# Altium schematic sheet N95Decon.SchDoc
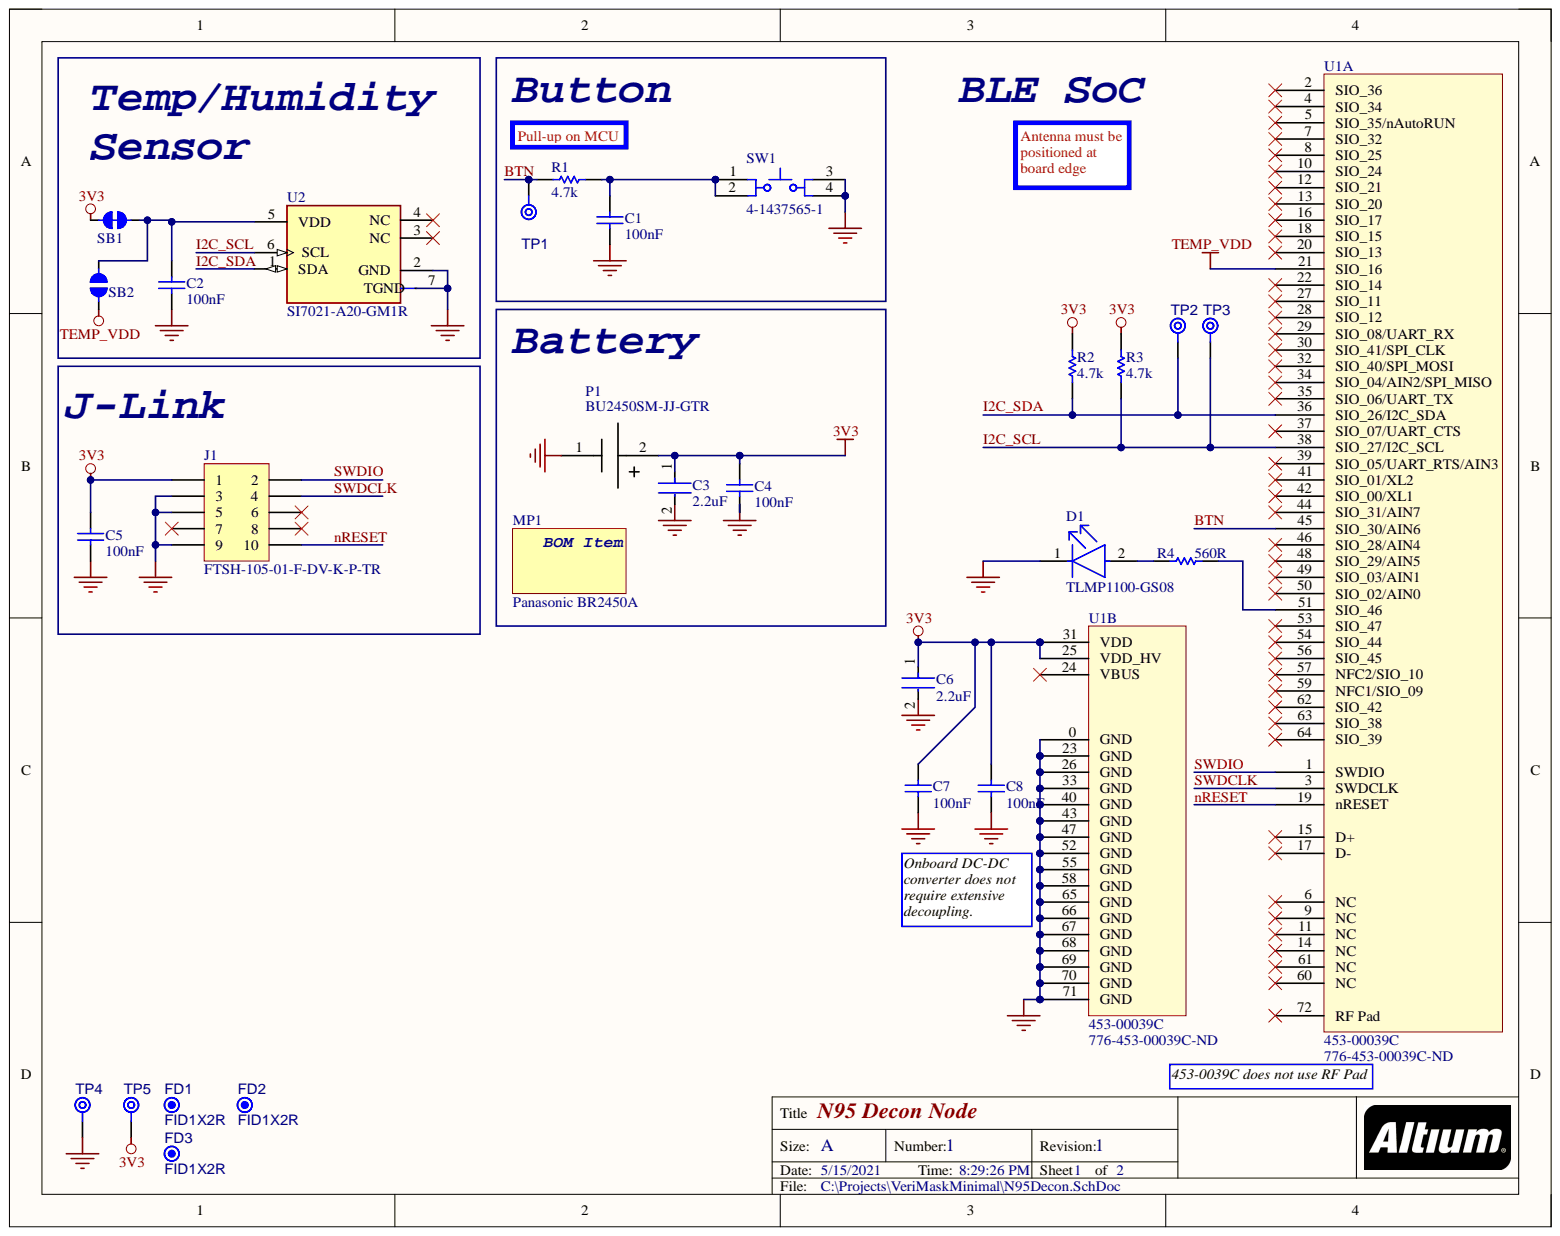
[diagram p0: N95Decon.SchDoc]

## Parts
C1  100nF  [CAPC1608X90X35LL15T15]
C2  100nF  [CAPC1608X90X35LL15T15]
C3  2.2uF  [FP-CL10-IPC_C]
C4  100nF  [CAPC1608X90X35LL15T15]
C5  100nF  [CAPC1608X90X35LL15T15]
C6  2.2uF  [FP-CL10-IPC_C]
C7  100nF  [CAPC1608X90X35LL15T15]
C8  100nF  [CAPC1608X90X35LL15T15]
D1  TLMP1100-GS08  [FP-6_541-5056_01-4-MFG]
FD1  FID1X2R  [FID1X2R]  (no BOM)
FD2  FID1X2R  [FID1X2R]  (no BOM)
FD3  FID1X2R  [FID1X2R]  (no BOM)
J1  FTSH-105-01-F-DV-K-P-TR  [FTSH-105-01-F-DV-K-P-TR-Footprint-1]
MP1  Panasonic BR2450A  Manufacturer 1: Panasonic  Manufacturer Part Number 1: BR2450A  (mechanical)
P1  BU2450SM-JJ-GTR  [BU2450SM-JJ-GTR]
R1  4.7k  [RESC1608X55X30LL15T15]
R2  4.7k  [RESC1608X55X30LL15T15]
R3  4.7k  [RESC1608X55X30LL15T15]
R4  560R  [RESC1608X55X30LL15T15]
SB1  Solder Bridge NC  [Jumper NC-Footprint-1]  (value not drawn)
SB2  Solder Bridge  [Solder Bridge-Footprint-1]  (value not drawn)  (no BOM)
SW1  4-1437565-1  [TECO-4-1437565-1_V]
TP1  BTN  [TP06R]  (no BOM)
TP2  SDA  [TP06R]  (value not drawn)  (no BOM)
TP3  SCL  [TP06R]  (value not drawn)  (no BOM)
TP4  GND  [TP06R]  (value not drawn)  (no BOM)
TP5  BAT  [TP06R]  (value not drawn)  (no BOM)
U1  453-00039C  Supplier Part Number 1: 776-453-00039C-ND  [453-00039C]  (parts A,B)
U2  SI7021-A20-GM1R  [FP-SI7021-A20-GM1R-MFG]

## Nets
3V3: C3.1 C4.2 C5.2 C6.1 C7.2 C8.2 J1.1 P1.2 R2.2 R3.2 SB1.1 TP5.1 U1.25(VDD_HV) U1.31(VDD)
GND: C1.1 C2.1 C3.2 C4.1 C5.1 C6.2 C7.1 C8.1 D1.1 J1.3 J1.5 J1.9 P1.1 SW1.3 SW1.4 TP4.1 U1.0(GND) U1.23(GND) U1.26(GND) U1.33(GND) U1.40(GND) U1.43(GND) U1.47(GND) U1.52(GND) U1.55(GND) U1.58(GND) U1.65(GND) U1.66(GND) U1.67(GND) U1.68(GND) U1.69(GND) U1.70(GND) U1.71(GND) U2.2(GND) U2.7(TGND)
TEMP_VDD: SB2.1 U1.21(SIO_16)
BTN: R1.2 TP1.1 U1.45(SIO_30/AIN6)
I2C_SCL: R3.1 TP3.1 U1.38(SIO_27/I2C_SCL) U2.6(SCL)
I2C_SDA: R2.1 TP2.1 U1.36(SIO_26/I2C_SDA) U2.1(SDA)
nRESET: J1.10 U1.19(nRESET)
SWDCLK: J1.4 U1.3(SWDCLK)
SWDIO: J1.2 U1.1(SWDIO)
NetC1_2: C1.2 R1.1 SW1.1 SW1.2
NetC2_2: C2.2 SB1.2 SB2.2 U2.5(VDD)
NetD1_2: D1.2 R4.1
NetR4_2: R4.2 U1.51(SIO_46)

Not connected: J1 6 7 8; U1 2(SIO_36) 4(SIO_34) 5(SIO_35/nAutoRUN) 6(NC) 7(SIO_32) 8(SIO_25) 9(NC) 10(SIO_24) 11(NC) 12(SIO_21) 13(SIO_20) 14(NC) 15(D+) 16(SIO_17) 17(D-) 18(SIO_15) 20(SIO_13) 22(SIO_14) 24(VBUS) 27(SIO_11) 28(SIO_12) 29(SIO_08/UART_RX) 30(SIO_41/SPI_CLK) 32(SIO_40/SPI_MOSI) 34(SIO_04/AIN2/SPI_MISO) 35(SIO_06/UART_TX) 37(SIO_07/UART_CTS) 39(SIO_05/UART_RTS/AIN3) 41(SIO_01/XL2) 42(SIO_00/XL1) 44(SIO_31/AIN7) 46(SIO_28/AIN4) 48(SIO_29/AIN5) 49(SIO_03/AIN1) 50(SIO_02/AIN0) 53(SIO_47) 54(SIO_44) 56(SIO_45) 57(NFC2/SIO_10) 59(NFC1/SIO_09) 60(NC) 61(NC) 62(SIO_42) 63(SIO_38) 64(SIO_39) 72(RF_Pad); U2 3(NC) 4(NC)

## Library data of the parts
C1 C2 C4 C5 C7 C8 = CMP-2006-02126-1: Manufacturer: AVX Interconnect / Elco; Manufacturer Part Number: 0603YC104MAT4A; Dielectric: X7R; Tolerance: 20%; Voltage Rating: 16V; Capacitance: 100nF; Case Code (Imperial): 0603; Case Code (Metric): 1608; Case/Package: 0603; Category: Ceramic Capacitors; Component Kind: Standard; ComponentLink1Description: https://datasheet.ciiva.com/6277/cx7r-6277724.pdf?src-supplier=Digi-Key; ComponentLink1URL: https://datasheet.ciiva.com/6277/cx7r-6277724.pdf?src-supplier=Digi-Key; Depth: 0.81mm; Length: 0.063inch; Library Name: Altium Content Vault; Max Operating Temperature: 125°C; Min Operating Temperature: -55°C; Mount: Surface Mount; Number of Pins: 2; Package Quantity: 15000; Packaging: Tape and Reel; Part Number: 0603YC104MAT4A; Pricing 1: 1=0.10000, 10=0.03200, 50=0.01720, 100=0.01410, 500=0.01006, 1000=0.00791, 2500=0.00719, 5000=0.00661 (USD); Radiation Hardening: No; RoHS: Yes; RoHS Compliant: Yes; Series: -; Stock 1: 5569; Supplier 1: Digi-Key; Supplier Part Number 1: 478-7617-1-ND; Termination: SMD/SMT; Thickness: 0.035inch; Voltage Rating (DC): 16V; Width: 0.032inch
C3 C6 = CMP-13271-002410-1: Cap Ceramic 2.2uF 6.3V X5R ±10% Pad SMD 0603 +85°C T/R; Capacitance: 2.2uF; Case Code (Imperial): 0603; Case Code (Metric): 1608; Case/Package: 0603; Component Kind: Standard; Contact Plating: Tin; Depth: 0.8mm; Dielectric: X5R; Height: 0.8mm; Lead Free: Lead Free; Length: 1.6mm; Library Name: Altium Content Vault; Max Operating Temperature: 85°C; Min Operating Temperature: -55°C; Mount: Surface Mount; Package Quantity: 1; Packaging: Tape and Reel; Radiation Hardening: No; Thickness: 0.035inch; Tolerance: 10%; Voltage: 6.3V; Voltage Rating: 6.3V; Voltage Rating (DC): 6.3V; Width: 0.031inch
D1 = CMP-02520-000009-1: Green 561nm LED Indication - Discrete 2.1V 0603 (1608 Metric); Manufacturer 1: Vishay; Automotive Grade: Grade 3; Colour: Pure green; Forward Current: 20mA; Led Orientation: Top View; Luminous Intensity: 15mcd; Max Junction Temp: +120°C; Peak Emmision Wavelength: 562nm; Power Dissipation: 90mW; Reverse Voltage: 6V; Viewing Angle: 160°; Ambient Temperature Range High: +100°C; Ambient Temperature Range Low: -40°C; Automotive: Yes; Category: Diode; Component Kind: Standard; ComponentLink2Description: Datasheet; ComponentLink2URL: http://www.vishay.com/docs/83173/tlmb1100.pdf; Device Class L1: Optoelectronics; Device Class L2: LEDs; Device Class L3: unset; Digikey Description: LED GREEN 0603 SMD; Forward Voltage: 2.1V; Height: 0.65mm; Lead Free: Yes; Library Name: Altium Content Vault; Manufacturer Part Number 1: TLMP1100-GS08; Mouser Description: Standard LEDs - SMD Pure Green; Octopart: https://octopart.com/tlmp1100-gs08-vishay-55433993; Package: 6.541-5056.01-4; RoHS: TRUE; Temperature: to +120°C; Temperature Range High: +120°C; Wavelength: 561nm
FD1-FD3 = CMP-00028-00005-1: Fiducial - Round 1x2mm; Pins: 0; Library Name: Ka Moamoa
J1 = CMP-00000-3: CONN HEADER SMD 10POS 1.27MM; Connector Type: Male Pin; Number of Contacts: 10; Row Spacing: 0.05inch; Color: Black; Component Kind: Standard; Contact Material: Bronze; Contact Mating Length: 0.12inch; Contact Plating: Gold; Depth: 5.84mm; Housing Color: Black; Housing Material: Polymer; Lead Free: Lead Free; Length: 6.35mm; Max Operating Temperature: 125°C; Min Operating Temperature: -55°C; Mount: Surface Mount; Number of Positions: 10; Number of Rows: 2; Orientation: Straight; Package Quantity: 500; Packaging: Tape and Reel; Pitch: 1.27mm; Radiation Hardening: No; Termination: Solder; Library Name: Ka Moamoa
MP1 = BOM Item: Supplier 1: Mouser; Library Name: N95Decon.SchLib
P1 = BU2450SM-JJ-GTR: BATTERY HOLDER COIN 24.5MM SMD; Manufacturer 1: Memory Protection Devices; Number of Cells: 1; Case/Package: SMD/SMT; Category: Uncategorized; Component Kind: Standard; ComponentLink1Description: https://datasheet.ciiva.com/4/bu2450sm-jj-gtr-datasheet-4338.pdf?src-supplier=Digi-Key; ComponentLink1URL: https://datasheet.ciiva.com/4/bu2450sm-jj-gtr-datasheet-4338.pdf?src-supplier=Digi-Key; ComponentLink2Description: https://datasheet.ciiva.com/4/bu2450sm-jj-gtr-datasheet-4339.pdf?src-supplier=Digi-Key; ComponentLink2URL: https://datasheet.ciiva.com/4/bu2450sm-jj-gtr-datasheet-4339.pdf?src-supplier=Digi-Key; ComponentLink3Description: https://datasheet.ciiva.com/4/bu2450sm-jj-gtr-datasheet-4340.pdf?src-supplier=Digi-Key; ComponentLink3URL: https://datasheet.ciiva.com/4/bu2450sm-jj-gtr-datasheet-4340.pdf?src-supplier=Digi-Key; Manufacturer Part Number 1: BU2450SM-JJ-GTR; Max Operating Temperature: 315°C; Min Operating Temperature: -40°C; Mount: Surface Mount,PCB; Package Quantity: 250; Pricing 1: 1=1.57000, 10=1.31900, 50=1.15920, 100=1.09220 (USD); RoHS: Yes; Stock 1: 20312; Supplier 1: Digi-Key; Termination: SMD/SMT; Library Name: N95Decon.SchLib
R1-R3 = CMP-2000-00796-1: Manufacturer: Panasonic; Part Number: ERJ-3GEYJ472V; Component Kind: Standard; Library Name: Altium Content Vault
R4 = CMP-2000-00808-1: Manufacturer: Panasonic; Part Number: ERJ-3GEYJ561V; Component Kind: Standard; Library Name: Altium Content Vault
SB1 = CMP-009-00178-4: Normally closed solder jumper; Component Kind: Net Tie; Library Name: Ka Moamoa
SB2 = CMP-009-00179-1: Normally open solder jumper; Library Name: Ka Moamoa
SW1 = CMP-2000-05602-1: Tactile Switch, 50 mA, 12 VDC, -20 to 85 degC, 4-Pin SMD, RoHS, Bulk; Manufacturer 1: TEConnectivity/AMPBrand; Manufacturer Part Number 1: FSM4JSMATR; Actuator Length: 1.4mm; Actuator Style: RoundButton; Body Orientation: Straight; Contact Rating @ Voltage: 0.05@12VDCA; Dielectric Strength: 500VAC; Electrical Life: 100000Cycle; Ground Terminal: No; Illumination Type: N/R; Maximum Contact Resistance: 100mOhm; Maximum DC Voltage Rating: 12V; Operating Force: 1.57N; Actuator Color: N/A; Base Material: N/A; Component Kind: Standard; Contact Material: CopperAlloy; Contact Plating: Silver; Datasheet URL: https://datasheet.ciiva.com/14635/0900766b80912216-14635826.pdf; Datasheet Version: 04/2007; Dimension: 6x10x5.25mm; Housing Material: N/A; Library Name: Altium Content Vault; Manufacturer URL: http://www.te.com/; Maximum AC Voltage Rating: N/A; Mechanical Life: N/A; Minimum Insulation Resistance: 100MOhm; Mounting: SurfaceMount,GullWing; Mounting Technology: SurfaceMount; Operating Temperature: -20to85C; Package Description: 4-Pin Surface Mount Device, Body 6 x 6 mm, Pitch 9.1 mm; Package Reference: 4-1437565-1; Packaging: Bulk; Part No: 4-1437565-1; Pole Throw Configuration: N/A; Product Weight: N/A; RoHS: Yes; Seal Description: N/A; Seal Location: N/A; Switch Configuration: N/A; Type: N/A
TP1-TP5 = CMP-00028-00002-2: 0.6mm round test point; Pins: 1; Library Name: Ka Moamoa
U1 = 453-00039C: BLE module (Nordic nRF52833)  Integrated antenna (Cut Tape)- BL653 series; Manufacturer 1: Laird; Component Kind: Standard; ComponentLink1Description: http://datasheet.octopart.com/453-00039C-Laird-datasheet-141265230.pdf?src-supplier=Future+Electronics; ComponentLink1URL: http://datasheet.octopart.com/453-00039C-Laird-datasheet-141265230.pdf?src-supplier=Future+Electronics; Manufacturer Part Number 1: 453-00039C; Pricing 1: 1=6.99000, 50=6.87000, 100=6.77500 (USD); Stock 1: 475; Supplier 1: Digi-Key; Library Name: N95Decon.SchLib
U2 = CMP-08164-000003-1: SENS HUMID/TEMP 3.6V I2C 2% 6DFN; Manufacturer: Silicon Labs; Min Supply Voltage: 1.9V; Operating Supply Current: 90-3500uA; Resolution: 12bits; Standoff Height: 0mm; Ambient Temperature Range High: +85°C; Ambient Temperature Range Low: -40°C; Automotive: No; Category: IC; Component Kind: Standard; ComponentLink2Description: Datasheet; ComponentLink2URL: https://www.silabs.com/documents/public/data-sheets/Si7021-A20.pdf; Device Class L1: Sensors; Device Class L2: Temperature and Humidity Sensors; Device Class L3: unset; Digikey Description: SENS HUMID/TEMP 3.6V I2C 2% 6DFN; Height: 1.21mm; Interface: I2C; Ipc Land Pattern Name: DFN300X300X121-6; Lead Free: Yes; Library Name: Altium Content Vault; Manufacturer Part Number: SI7021-A20-GM1R; Max Supply Voltage: 3.6V; Mouser Description: Humidity Temperature Sensor 0 ~ 100% RH I²C ±3% RH 18S Surface Mount; Octopart: https://octopart.com/si7021-a20-gm1r-silicon+labs-52675244; Package: 0; RoHS: TRUE; Sensor Output: I2C; Temperature: -40°C to +85°C; Temperature Range High: +85°C; Temperature Range Low: -40°C
